# Altium schematic sheet karmanDB_power.SchDoc
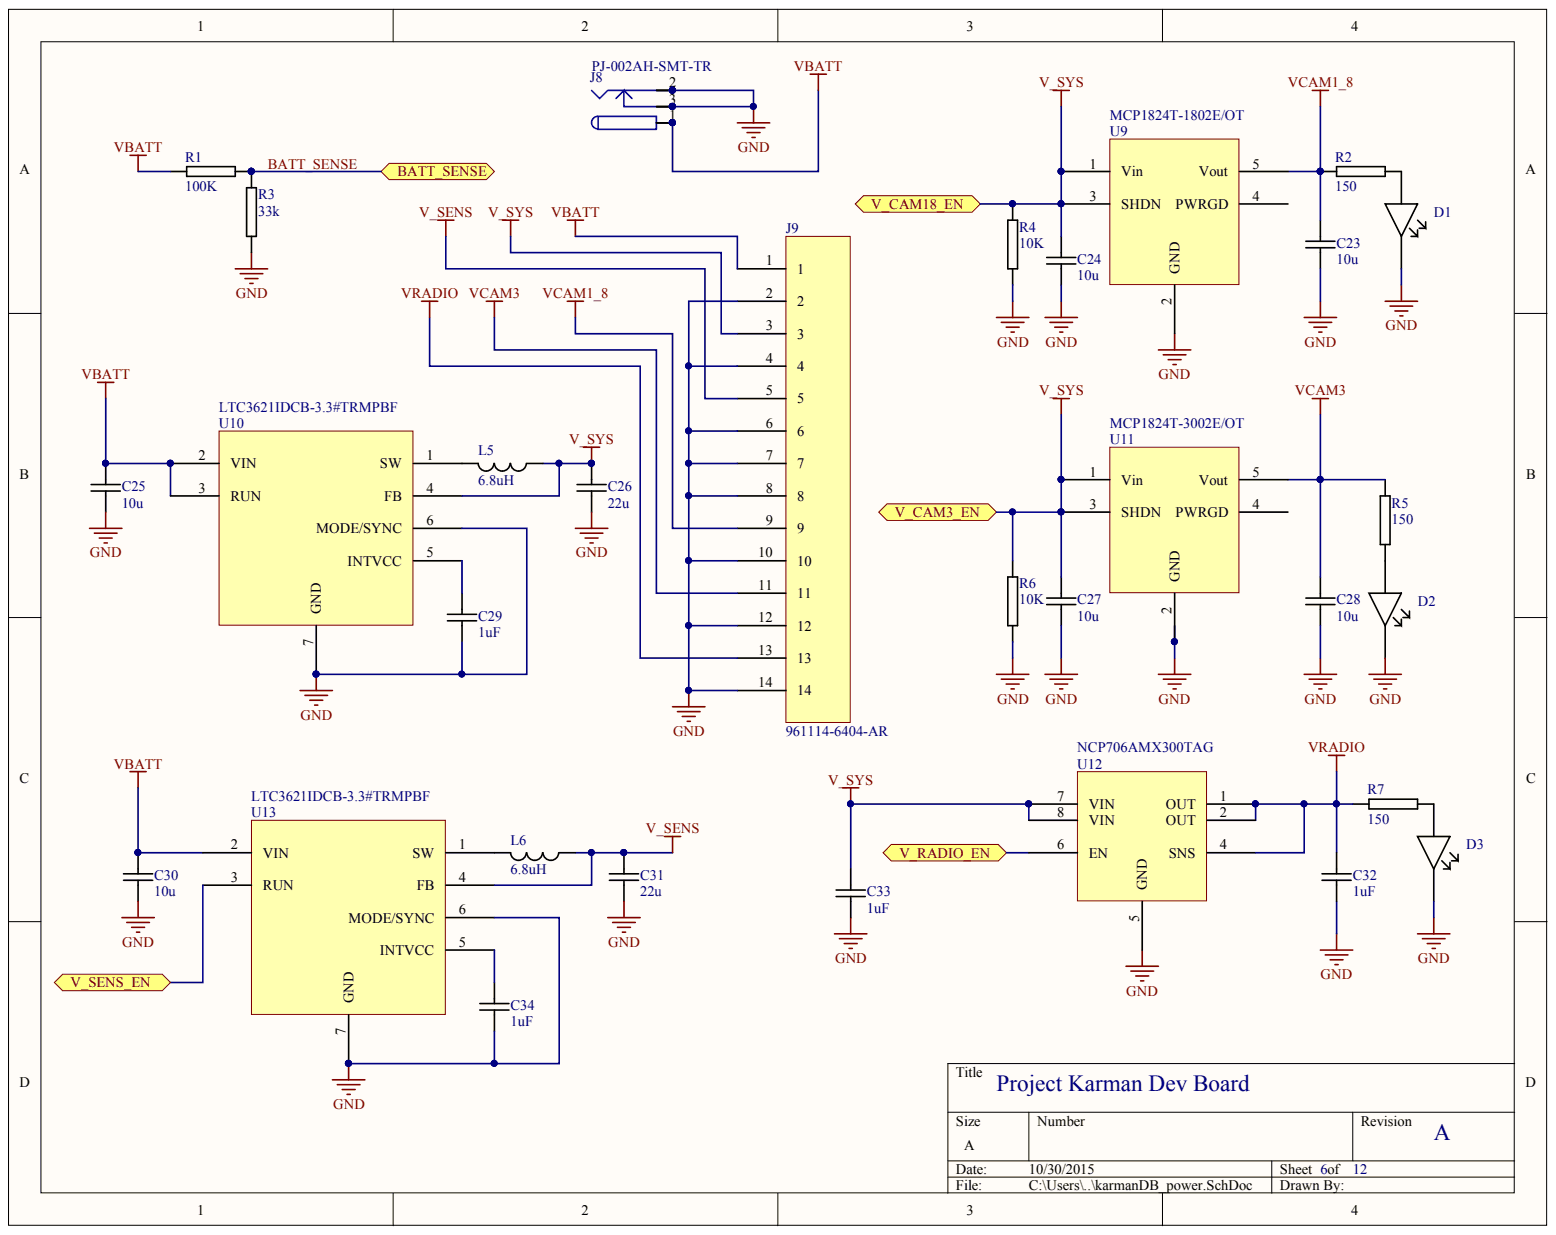
[diagram p0: karmanDB_power.SchDoc]

## Parts
C23  10u  [MURATA_GRM_0603]
C24  10u  [MURATA_GRM_0603]
C25  10u  [MURATA_GRM_0603]
C26  22u  [MURATA_GRM_0603]
C27  10u  [MURATA_GRM_0603]
C28  10u  [MURATA_GRM_0603]
C29  1uF  [MURATA_GRM_0402]
C30  10u  [MURATA_GRM_0603]
C31  22u  [MURATA_GRM_0603]
C32  1uF  [MURATA_GRM_0402]
C33  1uF  [MURATA_GRM_0402]
C34  1uF  [MURATA_GRM_0402]
D1  LED_LITEON_LTST-C150CKT  [LITEON_LTST-C150]  (value not drawn)
D2  LED_LITEON_LTST-C150CKT  [LITEON_LTST-C150]  (value not drawn)
D3  LED_LITEON_LTST-C150CKT  [LITEON_LTST-C150]  (value not drawn)
J8  PJ-002AH-SMT-TR
J9  961114-6404-AR
L5  6.8uH
L6  6.8uH
R1  100K  [PANASONIC_ERJ3R]
R2  150  [PANASONIC_ERJ3R]
R3  33k
R4  10K  [MURATA_GRM_0603]
R5  150  [PANASONIC_ERJ3R]
R6  10K  [MURATA_GRM_0603]
R7  150  [PANASONIC_ERJ3R]
U9  MCP1824T-1802E/OT
U10  LTC3621IDCB-3.3#TRMPBF
U11  MCP1824T-3002E/OT
U12  NCP706AMX300TAG
U13  LTC3621IDCB-3.3#TRMPBF

## Ports
BATT_SENSE, V_CAM3_EN, V_CAM18_EN, V_RADIO_EN, V_SENS_EN

## Nets
GND: C23.2 C24.2 C25.2 C26.2 C27.2 C28.2 C29.2 C30.2 C31.2 C32.2 C33.2 C34.2 D1.K D2.K D3.K J8.2 J8.2A J8.2B J8.3 J8.3A J8.3B J9.2 J9.4 J9.6 J9.7 J9.8 J9.10 J9.12 J9.14 R3.2 R4.2 R6.2 U9.2(GND) U10.6(MODE/SYNC) U10.7(GND) U11.2(GND) U12.5(GND) U13.6(MODE/SYNC) U13.7(GND)
V_SENS: C31.1 J9.5 L6.1 U13.4(FB)
VBATT: C25.1 C30.1 J8.1 J8.1A J8.1B J9.1 R1.1 U10.2(VIN) U10.3(RUN) U13.2(VIN)
VCAM1_8: C23.1 J9.9 R2.2 U9.5(Vout)
VCAM3: C28.1 J9.11 R5.1 U11.5(Vout)
VRADIO: C32.1 J9.13 R7.1 U12.1(OUT) U12.2(OUT) U12.4(SNS)
BATT_SENSE: R1.2 R3.1 ; port BATT_SENSE
V_RADIO_EN: U12.6(EN) ; port V_RADIO_EN
V_SENS_EN: U13.3(RUN) ; port V_SENS_EN
V_SYS: C24.1 C26.1 C27.1 C33.1 J9.3 L5.1 R4.1 R6.1 U9.1(Vin) U9.3(SHDN) U10.4(FB) U11.1(Vin) U11.3(SHDN) U12.7(VIN) U12.8(VIN) ; port V_CAM18_EN, port V_CAM3_EN
NetC29_1: C29.1 U10.5(INTVCC)
NetC34_1: C34.1 U13.5(INTVCC)
NetD1_A: D1.A R2.1
NetD2_A: D2.A R5.2
NetD3_A: D3.A R7.2
NetL5_2: L5.2 U10.1(SW)
NetL6_2: L6.2 U13.1(SW)

Not connected: U9 4(PWRGD); U11 4(PWRGD)

## Library data of the parts
C23-C25 C27 C28 C30 = CAP_MURATA_GRM188R60J106: CAP CER 10UF 6.3V 20% X5R 0603; Manufacturer: Murata Electronics North America; Manufacturer Part Number: GRM188R60J106ME47J; Capacitance: 10µF; Applications: General Purpose; Category: Capacitors; Component Kind: Standard; ComponentLink1Description: http://www.murata.com/~/media/webrenewal/support/library/catalog/products/capacitor/mlcc/c02e.ashx; ComponentLink1URL: http://www.murata.com/~/media/webrenewal/support/library/catalog/products/capacitor/mlcc/c02e.ashx; Features: -; Height - Seated (Max): -; Lead Spacing: -; Lead Style: -; Library Name: project_symbols.SchLib; Mounting Type: Surface Mount, MLCC; Operating Temperature: -55°C ~ 85°C; Package / Case: 0603 (1608 Metric); Packaging: Cut Tape (CT); Pricing: 1=0.19, 10=0.128, 50=0.0776, 100=0.0651, 250=0.05272, 500=0.0465, 1000=0.03953, 5000=0.03333 (USD); Ratings: -; RoHS: RoHS Compliant; Size / Dimension: 0.063" L x 0.031" W (1.60mm x 0.80mm); Stock 1: 159118; Supplier 1: Digi-Key; Supplier Part Number 1: 490-6405-1-ND; Temperature Coefficient: X5R; Thickness (Max): 0.035" (0.90mm); Tolerance: ±20%; Voltage - Rated: 6.3V
C26 C31 = CAP_MURATA_GRM188R61A226ME15D: CAP CER 22UF 10V 20% X5R 0603; Manufacturer: Murata Electronics North America; Capacitance: 22µF; Applications: General Purpose; Category: Capacitors; Component Kind: Standard; ComponentLink1Description: http://www.murata.com/~/media/webrenewal/support/library/catalog/products/capacitor/mlcc/c02e.ashx; ComponentLink1URL: http://www.murata.com/~/media/webrenewal/support/library/catalog/products/capacitor/mlcc/c02e.ashx; Features: -; Height - Seated (Max): -; Lead Spacing: -; Lead Style: -; Library Name: project_symbols.SchLib; Manufacturer Part Number: GRM188R61A226ME15D; Mounting Type: Surface Mount, MLCC; Operating Temperature: -55°C ~ 85°C; Package / Case: 0603 (1608 Metric); Packaging: Cut Tape (CT); Pricing: 1=0.3, 10=0.249, 25=0.1712, 50=0.14, 100=0.1244, 250=0.09332, 500=0.08242, 1000=0.07309 (USD); Ratings: -; RoHS: RoHS Compliant; Size / Dimension: 0.063" L x 0.031" W (1.60mm x 0.80mm); Stock 1: 2895; Supplier 1: Digi-Key; Supplier Part Number 1: 490-10476-1-ND; Temperature Coefficient: X5R; Thickness (Max): 0.039" (1.00mm); Tolerance: ±20%; Voltage - Rated: 10V
C29 C32-C34 = CAP_MURATA_GRM155R61A105KE15D: CAP CER 1uF 10volts X5R 10% 0402; Brand: Murata Electronics; Type: General Purpose MLCC; Voltage Rating DC: 10 V; Capacitance: 1 uF; Case Code - in: 0402; Case Code - mm: 1005; Category: Multilayer Ceramic Capacitors MLCC - SMD/SMT; Component Kind: Standard; ComponentLink1Description: http://www.mouser.com/ds/2/281/c02e-2905.pdf; ComponentLink1URL: http://www.mouser.com/ds/2/281/c02e-2905.pdf; Dielectric: X5R; Height: 0.5 mm; Length: 1 mm; Library Name: project_symbols.SchLib; Manufacturer: Murata Electronics; Manufacturer Part Number: GRM155R61A105KE15D; Maximum Operating Temperature: + 85 C; Minimum Operating Temperature: - 55 C; Minimum Order Quantity: 1; Package / Case: 0402 (1005 metric); Packaging: Reel; Pricing 1: 1=0.528, 10=0.066, 100=0.038, 1000=0.030, 2500=0.026, 10000=0.021, 20000=0.019, 50000=0.016, 100000=0.014 (AUD); Product: General Type MLCCs; Product Category: High Capacitance SMD Ceramic Chip Capacitors; Reeling: True; RoHS: RoHS Compliant; RoHS - Mouser: Y; Series: GRM; Standard Pack Qty: 10000; Stock 1: 726006; Supplier 1: Mouser; Supplier Part Number 1: 81-GRM155R61A105KE15; Termination Style: SMD/SMT; Tolerance: 10 %; Width: 0.5 mm
D1-D3 = LED_LITEON_LTST-C150CKT: LED RED CLEAR 1206 SMD; Manufacturer: Lite-On Inc; Current - Test: 10mA; Lens Color: Colorless; Lens Style/Size: Rectangle with Flat Top, 2.06mm x 1.60mm; Millicandela Rating: 10mcd; Viewing Angle: 130°; Voltage - Forward (Vf) (Typ): 1.8V; Wavelength - Dominant: 638nm; Wavelength - Peak: 660nm; Category: Optoelectronics; Color: Red; Component Kind: Standard; ComponentLink1Description: http://optoelectronics.liteon.com/upload/download/DS-22-98-0002/S_110_LTST-C150CKT.pdf; ComponentLink1URL: http://optoelectronics.liteon.com/upload/download/DS-22-98-0002/S_110_LTST-C150CKT.pdf; Height (Max): 1.10mm; Lens Transparency: Clear; Library Name: project_symbols.SchLib; Manufacturer Part Number: LTST-C150CKT; Mounting Type: Surface Mount; Package / Case: 1206 (3216 Metric); Packaging: Cut Tape (CT); Pricing: 1=0.4, 10=0.297, 100=0.1574, 250=0.11136, 500=0.09504, 1000=0.07425 (USD); RoHS: RoHS Compliant; Size / Dimension: 3.20mm L x 1.60mm W; Stock 1: 90600; Supplier 1: Digi-Key; Supplier Device Package: 1206; Supplier Part Number 1: 160-1167-1-ND
J8 = PJ-002AH-SMT-TR: CONN POWER JACK 2.1X5.5MM HI CUR; Manufacturer: CUI Inc.; Current Rating: 5A; Gender: Male; Internal Switch(s): Single Switch, Normally Closed; Number of Positions/Contacts: 2 Conductors, 3 Contacts; Plug/Mating Plug Diameter: 2.1mm ID, 5.5mm OD; Shielding: Unshielded; Voltage - Rated: 24VDC; Category: Connectors, Interconnects; Color: Black; Component Kind: Standard; ComponentLink1Description: http://www.cui.com/product/resource/digikeypdf/pj-002ah-smt.pdf; ComponentLink1URL: http://www.cui.com/product/resource/digikeypdf/pj-002ah-smt.pdf; Connector Type: Jack; Contact Material: Copper Alloy; Contact Material - Plating: Nickel; Features: -; Housing Color: Black; Housing Material: Thermoplastic; Manufacturer Part Number: PJ-002AH-SMT-TR; Mounting Type: Surface Mount; Operating Temperature: -25°C ~ 85°C; Pricing 1: 1=1.89, 10=1.469, 100=1.121 (USD); RoHS: RoHS Compliant; Stock 1: 15720; Supplier 1: Digi-Key; Supplier Part Number 1: CP-002AHPJCT-ND; Termination: Solder; Library Name: karman_pcb.SCHLIB
J9 = 961114-6404-AR: CONN HEADER VERT SGL 14POS GOLD; Manufacturer: 3M; Connector Type: Header, Unshrouded; Number of Positions: 14; Number of Positions Loaded: All; Pitch: 0.100" (2.54mm); Category: Connectors, Interconnects; Color: Black; Component Kind: Standard; ComponentLink1Description: http://multimedia.3m.com/mws/mediawebserver?66666UuZjcFSLXTtNxTElX&VEVuQEcuZgVs6EVs6E666666--; ComponentLink1URL: http://multimedia.3m.com/mws/mediawebserver?66666UuZjcFSLXTtNxTElX&VEVuQEcuZgVs6EVs6E666666--; Contact Finish: Gold; Contact Finish Thickness: Flash; Contact Mating Length: 0.217" (5.50mm); Contact Type: Male Pin; Fastening Type: -; Features: -; Manufacturer Part Number: 961114-6404-AR; Mounting Type: Through Hole; Number of Rows: 1; Packaging: Bulk; Pricing 1: 1=0.96, 10=0.842, 25=0.792, 50=0.759, 100=0.726, 250=0.66, 500=0.594, 1000=0.528, 2500=0.4785 (USD); RoHS: RoHS Compliant; Row Spacing: -; Stock 1: 3241; Supplier 1: Digi-Key; Supplier Part Number 1: 3M9453-ND; Termination: Solder; Library Name: karman_pcb.SCHLIB
L5 L6 = TDK_IND_RLF7030T-6R8M2R8: FIXED IND 6.8UH 2.8A 37.3 MOHM; Manufacturer: TDK Corporation; Current - Saturation: 3A; DC Resistance (DCR): 44.76 mOhm Max; Frequency - Test: 100kHz; Inductance: 6.8µH; Material - Core: Ferrite; Shielding: Shielded; Tolerance: ±20%; Type: Wirewound; Category: Inductors, Coils, Chokes; Component Kind: Standard; ComponentLink1Description: http://product.tdk.com/inductor/ind/en/documents/inductor_commercial_power_rlf_en.pdf; ComponentLink1URL: http://product.tdk.com/inductor/ind/en/documents/inductor_commercial_power_rlf_en.pdf; Current Rating: 2.8A; Frequency - Self Resonant: -; Height - Seated (Max): 0.126" (3.20mm); Library Name: project_symbols.SchLib; Manufacturer Part Number: RLF7030T-6R8M2R8; Mounting Type: Surface Mount; Operating Temperature: -40°C ~ 125°C; Package / Case: Nonstandard; Packaging: Cut Tape (CT); Pricing 1: 1=2.31, 10=2.153, 50=1.7528, 100=1.599, 500=1.353 (USD); Q @ Freq: -; RoHS: RoHS Compliant; Size / Dimension: 0.287" L x 0.268" W (7.30mm x 6.80mm); Stock 1: 8675; Supplier 1: Digi-Key; Supplier Device Package: -; Supplier Part Number 1: 445-2965-1-ND
R1 = RES_PANASONIC_ERJ-3GEYJ104V: RES SMD 100K OHM 5% 1/10W 0603; Manufacturer: Panasonic Electronic Components; Power (Watts): 0.1W, 1/10W; Temperature Coefficient: ±200ppm/°C; Category: Resistors; Component Kind: Standard; ComponentLink1Description: http://industrial.panasonic.com/www-cgi/jvcr13pz.cgi?E+PZ+3+AOA0001+ERJ3GEYJ104V+7+WW; ComponentLink1URL: http://industrial.panasonic.com/www-cgi/jvcr13pz.cgi?E+PZ+3+AOA0001+ERJ3GEYJ104V+7+WW; Composition: Thick Film; Features: Automotive AEC-Q200; Height: 0.022" (0.55mm); Library Name: project_symbols.SchLib; Manufacturer Part Number: ERJ-3GEYJ104V; Number of Terminations: 2; Operating Temperature: -55°C ~ 155°C; Package / Case: 0603 (1608 Metric); Packaging: Cut Tape (CT); Pricing: 1=0.1, 50=0.0096, 100=0.0071, 250=0.00544, 500=0.00436, 1000=0.00321 (USD); Resistance (Ohms): 100k; RoHS: RoHS Compliant; Size / Dimension: 0.063" L x 0.031" W (1.60mm x 0.80mm); Stock 1: 1746081; Supplier 1: Digi-Key; Supplier Device Package: 0603; Supplier Part Number 1: P100KGCT-ND; Tolerance: ±5%
R2 R5 R7 = RES_PANASONIC_ERJ-3GEYJ151V: RES SMD 150 OHM 5% 1/10W 0603; Manufacturer: Panasonic Electronic Components; Power (Watts): 0.1W, 1/10W; Temperature Coefficient: ±200ppm/°C; Category: Resistors; Component Kind: Standard; ComponentLink1Description: http://industrial.panasonic.com/www-cgi/jvcr13pz.cgi?E+PZ+3+AOA0001+ERJ3GEYJ151V+7+WW; ComponentLink1URL: http://industrial.panasonic.com/www-cgi/jvcr13pz.cgi?E+PZ+3+AOA0001+ERJ3GEYJ151V+7+WW; Composition: Thick Film; Features: Automotive AEC-Q200; Height: 0.022" (0.55mm); Library Name: project_symbols.SchLib; Manufacturer Part Number: ERJ-3GEYJ151V; Number of Terminations: 2; Operating Temperature: -55°C ~ 155°C; Package / Case: 0603 (1608 Metric); Packaging: Cut Tape (CT); Pricing: 1=0.1, 50=0.0096, 100=0.0071, 250=0.00544, 500=0.00436, 1000=0.00321 (USD); Resistance (Ohms): 150; RoHS: RoHS Compliant; Size / Dimension: 0.063" L x 0.031" W (1.60mm x 0.80mm); Stock 1: 236723; Supplier 1: Digi-Key; Supplier Device Package: 0603; Supplier Part Number 1: P150GCT-ND; Tolerance: ±5%
R3 = RES_PANASONIC_ERJ-2GEJ333X: RES SMD 33K OHM 5% 1/10W 0402; Manufacturer: Panasonic Electronic Components; Power (Watts): 0.1W, 1/10W; Temperature Coefficient: ±200ppm/°C; Category: Resistors; Component Kind: Standard; ComponentLink1Description: http://industrial.panasonic.com/www-cgi/jvcr13pz.cgi?E+PZ+3+AOA0001+ERJ2GEJ333X+7+WW; ComponentLink1URL: http://industrial.panasonic.com/www-cgi/jvcr13pz.cgi?E+PZ+3+AOA0001+ERJ2GEJ333X+7+WW; Composition: Thick Film; Features: Automotive AEC-Q200; Height: 0.016" (0.40mm); Library Name: project_symbols.SchLib; Manufacturer Part Number: ERJ-2GEJ333X; Number of Terminations: 2; Operating Temperature: -55°C ~ 155°C; Package / Case: 0402 (1005 Metric); Packaging: Tape & Reel (TR); Pricing 1: 10000=0.00198, 30000=0.00174, 50000=0.0016, 100000=0.00156 (USD); Resistance (Ohms): 33k; RoHS: RoHS Compliant; Size / Dimension: 0.039" L x 0.020" W (1.00mm x 0.50mm); Stock 1: 860000; Supplier 1: Digi-Key; Supplier Device Package: 0402; Supplier Part Number 1: P33KJTR-ND; Tolerance: ±5%
R4 R6 = RES_PANASONIC_ERJ-3GEYJ103V: RES SMD 10K OHM 5% 1/10W 0603; Manufacturer: Panasonic Electronic Components; Power (Watts): 0.1W, 1/10W; Temperature Coefficient: ±200ppm/°C; Category: Resistors; Component Kind: Standard; ComponentLink1Description: http://industrial.panasonic.com/www-cgi/jvcr13pz.cgi?E+PZ+3+AOA0001+ERJ3GEYJ103V+7+WW; ComponentLink1URL: http://industrial.panasonic.com/www-cgi/jvcr13pz.cgi?E+PZ+3+AOA0001+ERJ3GEYJ103V+7+WW; Composition: Thick Film; Features: Automotive AEC-Q200; Height: 0.022" (0.55mm); Library Name: project_symbols.SchLib; Manufacturer Part Number: ERJ-3GEYJ103V; Number of Terminations: 2; Operating Temperature: -55°C ~ 155°C; Package / Case: 0603 (1608 Metric); Packaging: Cut Tape (CT); Pricing: 1=0.1, 50=0.0096, 100=0.0071, 250=0.00544, 500=0.00436, 1000=0.00321 (USD); Resistance (Ohms): 10k; RoHS: RoHS Compliant; Size / Dimension: 0.063" L x 0.031" W (1.60mm x 0.80mm); Stock 1: 4229597; Supplier 1: Digi-Key; Supplier Device Package: 0603; Supplier Part Number 1: P10KGCT-ND; Tolerance: ±5%
U9 = MCP1824T-1802E/OT: IC REG LDO 1.8V 0.3A SOT23-5; Manufacturer: Microchip Technology; Current - Output: 300mA; Number of Regulators: 1; Regulator Topology: Positive Fixed; Voltage - Dropout (Typical): 0.2V @ 300mA; Voltage - Input: 2.1 V ~ 6 V; Category: Integrated Circuits (ICs); Component Kind: Standard; ComponentLink1Description: http://www.microchip.com/mymicrochip/filehandler.aspx?ddocname=en533257; ComponentLink1URL: http://www.microchip.com/mymicrochip/filehandler.aspx?ddocname=en533257; Current - Limit (Min): -; Manufacturer Part Number: MCP1824T-1802E/OT; Mounting Type: Surface Mount; Operating Temperature: -40°C ~ 125°C; Package / Case: SC-74A, SOT-753; Packaging: Cut Tape (CT); Pricing 1: 1=0.5, 10=0.42, 25=0.35, 100=0.32 (USD); RoHS: RoHS Compliant; Stock 1: 10644; Supplier 1: Digi-Key; Supplier Device Package: SOT-23-5; Supplier Part Number 1: MCP1824T-1802E/OTCT-ND; Voltage - Output: 1.8V; Library Name: karman_pcb.SCHLIB
U10 U13 = LTC3621IDCB-3.3#TRMPBF: IC REG BUCK 3.3V 1A SYNC 6DFN; Manufacturer: Linear Technology; Frequency - Switching: 1MHz; Function: Step-Down; Number of Outputs: 1; Output Configuration: Positive; Output Type: Fixed; Synchronous Rectifier: Yes; Voltage - Input (Max): 17V; Voltage - Input (Min): 2.7V; Category: Integrated Circuits (ICs); Component Kind: Standard; ComponentLink1Description: http://www.linear.com/docs/42954; ComponentLink1URL: http://www.linear.com/docs/42954; Current - Output: 1A; Manufacturer Part Number: LTC3621IDCB-3.3#TRMPBF; Mounting Type: Surface Mount; Operating Temperature: -40°C ~ 125°C (TJ); Package / Case: 6-WFDFN Exposed Pad; Packaging: Cut Tape (CT); Pricing 1: 1=6.84, 25=6.1052, 100=5.4948 (USD); RoHS: RoHS Compliant; Stock 1: 490; Supplier 1: Digi-Key; Supplier Device Package: 6-DFN (2x3); Supplier Part Number 1: LTC3621IDCB-3.3#TRMPBFCT-ND; Topology: Buck; Voltage - Output (Max): -; Voltage - Output (Min/Fixed): 3.3V; Library Name: karman_pcb.SCHLIB
U11 = MCP1824T-3002E/OT: IC REG LDO 3V 0.3A SOT23-5; Manufacturer: Microchip Technology; Current - Output: 300mA; Number of Regulators: 1; Regulator Topology: Positive Fixed; Voltage - Dropout (Typical): 0.2V @ 300mA; Voltage - Input: Up to 6V; Category: Integrated Circuits (ICs); Component Kind: Standard; ComponentLink1Description: http://www.microchip.com/mymicrochip/filehandler.aspx?ddocname=en533257; ComponentLink1URL: http://www.microchip.com/mymicrochip/filehandler.aspx?ddocname=en533257; Current - Limit (Min): -; Manufacturer Part Number: MCP1824T-3002E/OT; Mounting Type: Surface Mount; Operating Temperature: -40°C ~ 125°C; Package / Case: SC-74A, SOT-753; Packaging: Cut Tape (CT); Pricing 1: 1=0.5, 10=0.42, 25=0.35, 100=0.32 (USD); RoHS: RoHS Compliant; Stock 1: 1155; Supplier 1: Digi-Key; Supplier Device Package: SOT-23-5; Supplier Part Number 1: MCP1824T-3002E/OTCT-ND; Voltage - Output: 3V; Library Name: karman_pcb.SCHLIB
U12 = NCP706AMX300TAG: IC REG LDO 3V 1A 8XDFN; Manufacturer: ON Semiconductor; Current - Limit (Min): 1.1A; Number of Regulators: 1; Regulator Topology: Positive Fixed; Voltage - Dropout (Typical): 0.155V @ 1A; Voltage - Input: Up to 5.5V; Category: Integrated Circuits (ICs); Component Kind: Standard; ComponentLink1Description: http://www.onsemi.com/pub_link/Collateral/NCP706A-D.PDF; ComponentLink1URL: http://www.onsemi.com/pub_link/Collateral/NCP706A-D.PDF; Current - Output: 1A; Manufacturer Part Number: NCP706AMX300TAG; Mounting Type: Surface Mount; Operating Temperature: -40°C ~ 125°C; Package / Case: 8-XFDFN Exposed Pad; Packaging: Cut Tape (CT); Pricing 1: 1=0.41, 10=0.348, 100=0.2601, 500=0.2043, 1000=0.1575 (USD); RoHS: RoHS Compliant; Stock 1: 3000; Supplier 1: Digi-Key; Supplier Device Package: 8-XDFN (1.6x1.2); Supplier Part Number 1: NCP706AMX300TAGOSCT-ND; Voltage - Output: 3V; Library Name: karman_pcb.SCHLIB
